# Altium schematic sheet AUDIO.SchDoc(AUDIO)
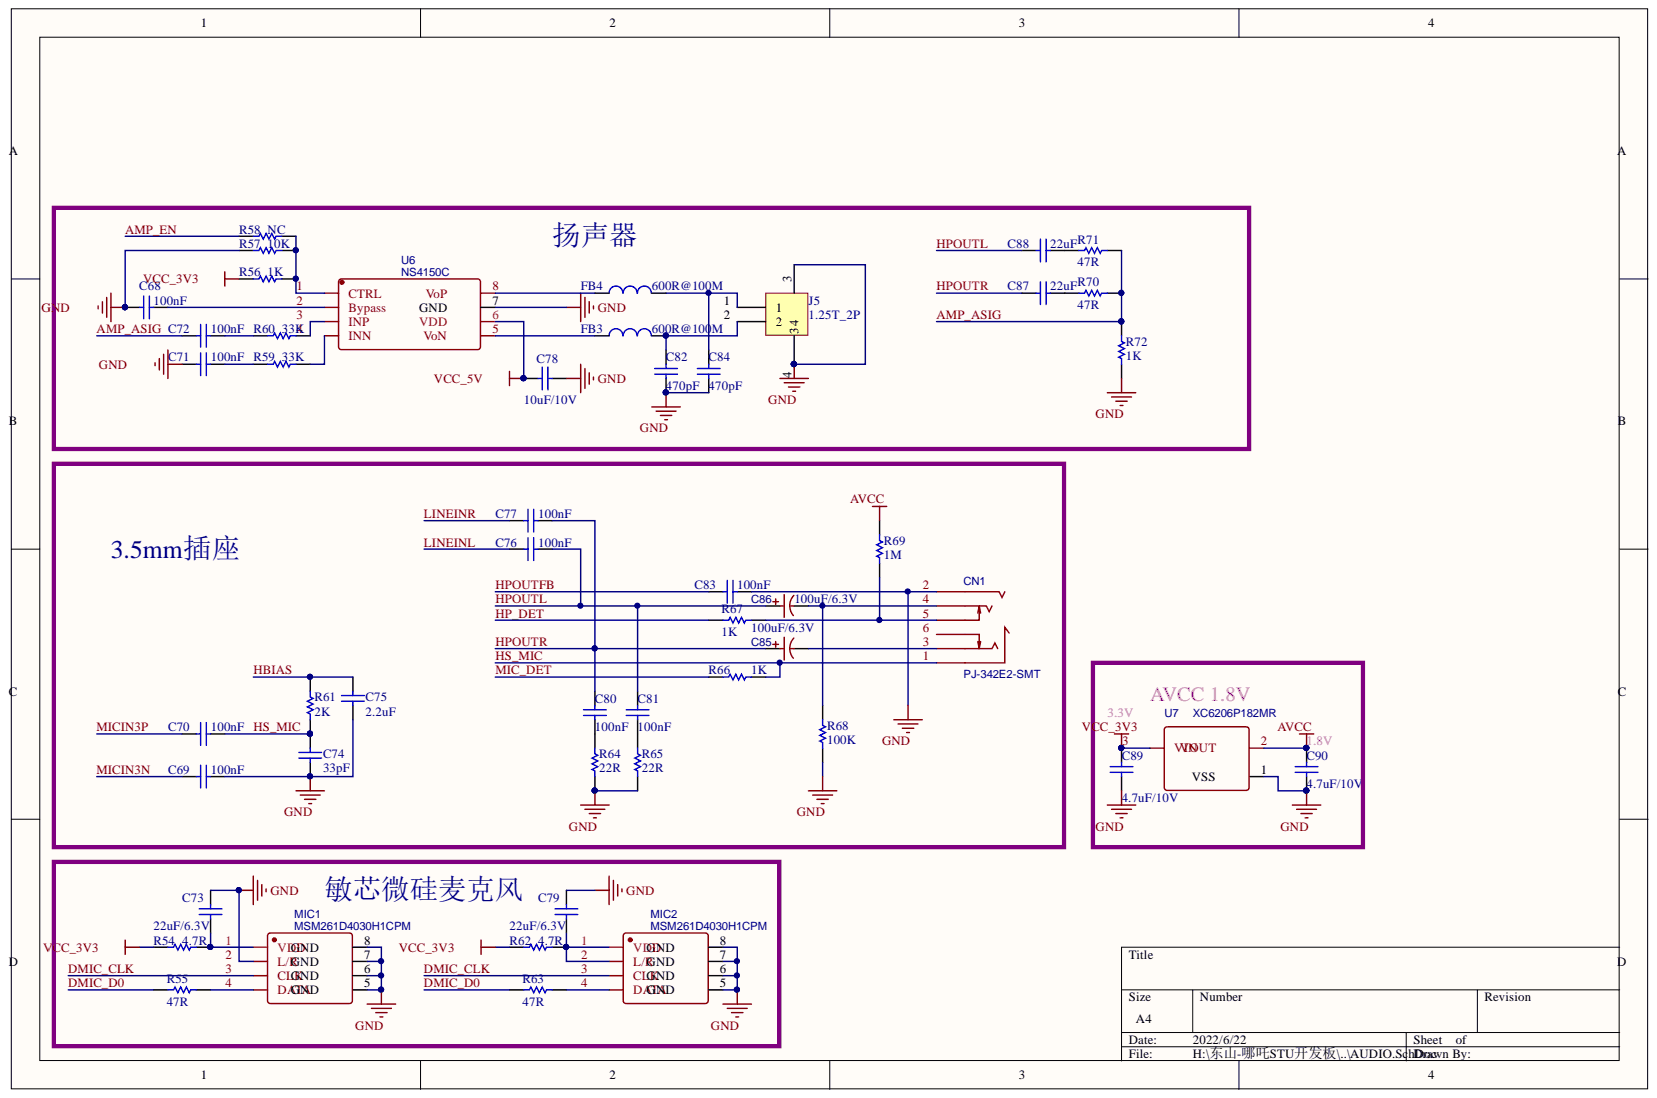
[diagram p0: AUDIO.SchDoc]

## Parts
C68  100nF  [0402]
C69  100nF  [0402]
C70  100nF  [0402]
C71  100nF  [0402]
C72  100nF  [0402]
C73  22uF/6.3V  [0402]
C74  33pF  [0402]
C75  2.2uF  [0402]
C76  100nF  [0402]
C77  100nF  [0402]
C78  10uF/10V  [0603]
C79  22uF/6.3V  [0402]
C80  100nF  [0402]
C81  100nF  [0402]
C82  470pF  [0402]
C83  100nF  [0402]
C84  470pF  [0402]
C85  100uF/6.3V  [CAP-SMD_CASE_B]
C86  100uF/6.3V  [CAP-SMD_CASE_B]
C87  22uF  [0402]
C88  22uF  [0402]
C89  4.7uF/10V  [0402]
C90  4.7uF/10V  [0402]
CN1  PJ-342E2-SMT  [AUDIO-SMD_PJ-342E2-SMT]
FB3  600R@100M  [0603]
FB4  600R@100M  [0603]
J5  1.25T_2P  [CONN-SMD_1.25T-2P]
MIC1  MSM261D4030H1CPM  [MIC-SMD_8P-L3.0-W4.0-P0.85-BL]
MIC2  MSM261D4030H1CPM  [MIC-SMD_8P-L3.0-W4.0-P0.85-BL]
R54  4.7R  [0402]
R55  47R  [0402]
R56  1K  [0402]
R57  10K  [0402]
R58  NC  [0402]
R59  33K  [0402]
R60  33K  [0402]
R61  2K  [0402]
R62  4.7R  [0402]
R63  47R  [0402]
R64  22R  [0402]
R65  22R  [0402]
R66  1K  [0402]
R67  1K  [0402]
R68  100K  [0402]
R69  1M  [0402]
R70  47R  [0402]
R71  47R  [0402]
R72  1K  [0402]
U6  NS4150C  [SOP-8_L4.9-W3.9-P1.27-LS6.0-BL]
U7  XC6206P182MR  [SOT23]

## Nets
AVCC: C90.1 R69.2 U7.2
GND: C68.2 C69.1 C71.2 C73.2 C74.2 C75.2 C78.1 C79.2 C82.2 C83.1 C84.2 C89.2 C90.2 CN1.2 J5.3 J5.4(3_4) MIC1.2(L/R) MIC1.5 MIC1.6 MIC1.7 MIC1.8 MIC2.5 MIC2.6 MIC2.7 MIC2.8 R57.1 R64.2 R65.2 R68.1 R72.2 U6.7 U7.1
VCC_3V3: C89.1 R54.2 R56.1 R62.2 U7.3
VCC_5V: C78.2 U6.6
AMP_ASIG: C72.2 R70.2 R71.2 R72.1
AMP_EN: R58.1
DMIC_CLK: MIC1.3(CLK) MIC2.3(CLK)
DMIC_D0: R55.2 R63.2
HBIAS: C75.1 R61.2
HP_DET: R67.2
HPOUTFB: C83.2
HPOUTL: C76.2 C81.1 C86.1 C88.2
HPOUTR: C77.2 C80.1 C85.1 C87.2
HS_MIC: C70.1 C74.1 CN1.1 R61.1 R66.1
LINEINL: C76.1
LINEINR: C77.1
MIC_DET: R66.2
MICIN3N: C69.2
MICIN3P: C70.2
NetC68_1: C68.1 U6.2(Bypass)
NetC71_1: C71.1 R59.1
NetC72_1: C72.1 R60.1
NetC73_1: C73.1 MIC1.1(VDD) R54.1
NetC79_1: C79.1 MIC2.1(VDD) MIC2.2(L/R) R62.1
NetC80_2: C80.2 R64.1
NetC81_2: C81.2 R65.1
NetC82_1: C82.1 FB3.2 J5.2
NetC84_1: C84.1 FB4.2 J5.1
NetC85_2: C85.2 CN1.3
NetC86_2: C86.2 CN1.4 R68.2
NetC87_1: C87.1 R70.1
NetC88_1: C88.1 R71.1
NetCN1_5: CN1.5 R67.1 R69.1
NetFB3_1: FB3.1 U6.5
NetFB4_1: FB4.1 U6.8
NetMIC1_4: MIC1.4(DATA) R55.1
NetMIC2_4: MIC2.4(DATA) R63.1
NetR56_2: R56.2 R57.2 R58.2 U6.1(CTRL)
NetR59_2: R59.2 U6.4(INN)
NetR60_2: R60.2 U6.3(INP)

Not connected: CN1 6

## Library data of the parts
C68-C84 C87-C90 = Cap: Capacitor; PartNumber: CAP100NF; Component Kind: Standard; LatestRevisionDate: 17-Jul-2002; LatestRevisionNote: Re-released for DXP Platform.; Library Name: Miscellaneous Devices.IntLib; PackageReference: 0402; Published: 8-Jun-2000; Signal Integrity: Cap; Simulation: CAP; Publisher: Altium Limited
  C68-C72 C76 C77 C80 C81 C83: Value: 100nF
  C73 C79: Value: 22uF/6.3V
  C74: Value: 33pF
  C75: Value: 2.2uF
  C78: Value: 10uF/10V
  C82 C84: Value: 470pF
  C87 C88: Value: 22uF
  C89 C90: Value: 4.7uF/10V
C85 C86 = 100uF: BOM_Manufacturer: ReliaPro; nameAlias: Capacitance; BOM_Supplier: LCSC; BOM_Supplier Part: C13376; Component Kind: Standard; spicePre: C; Value: 100uF/6.3V
CN1 = PJ-342E2-SMT: BOM_Manufacturer: XKB Connectivity; Contributor: LCSC; BOM_JLCPCB Part Class: Extended Part; BOM_Manufacturer Part: PJ-342E2-SMT; BOM_Supplier: LCSC; BOM_Supplier Part: C692439; Component Kind: Standard; spicePre: C; spiceSymbolName: PJ-342E2-SMT
FB3 FB4 = Inductor: Component Kind: Standard; Library Name: MyDevice.SchLib; Value: 600R@100M
MIC1 MIC2 = MSM261D4030H1CPM: Contributor: LCSC; BOM_JLCPCB Part Class: Extended Part; BOM_Manufacturer Part: MSM261D4030H1CPM; BOM_Supplier: LCSC; BOM_Supplier Part: C966942; Component Kind: Standard; spicePre: M; spiceSymbolName: MSM261D4030H1CPM
R54-R72 = Res1: Resistor; PartNumber: RES2.2K; Component Kind: Standard; LatestRevisionDate: 17-Jul-2002; LatestRevisionNote: Re-released for DXP Platform.; Library Name: Miscellaneous Devices.IntLib; PackageReference: 0402; Published: 8-Jun-2000; Signal Integrity: Res; Simulation: RESISTOR; Publisher: Altium Limited
  R54 R62: Value: 4.7R
  R55 R63 R70 R71: Value: 47R
  R56 R66 R67 R72: Value: 1K
  R57: Value: 10K
  R58: Value: NC
  R59 R60: Value: 33K
  R61: Value: 2K
  R64 R65: Value: 22R
  R68: Value: 100K
  R69: Value: 1M
U6 = NS4150C: Contributor: LCSC; BOM_JLCPCB Part Class: Extended Part; BOM_Manufacturer Part: NS4150C; BOM_Supplier: LCSC; BOM_Supplier Part: C2875838; Component Kind: Standard; spicePre: U; spiceSymbolName: NS4150C
U7 = XC6206P182MR_C2904834: Contributor: LCSC; BOM_Manufacturer Part: XC6206P182MR; BOM_Supplier: LCSC; BOM_Supplier Part: C2904834; Component Kind: Standard; Library Name: HM2.SchLib; spicePre: U; spiceSymbolName: XC6206P182MR_C2904834
J5 = 1.25T_2P: Component Kind: Standard; Library Name: HM2.SchLib
